# Altium schematic sheet LED.SchDoc(LED)
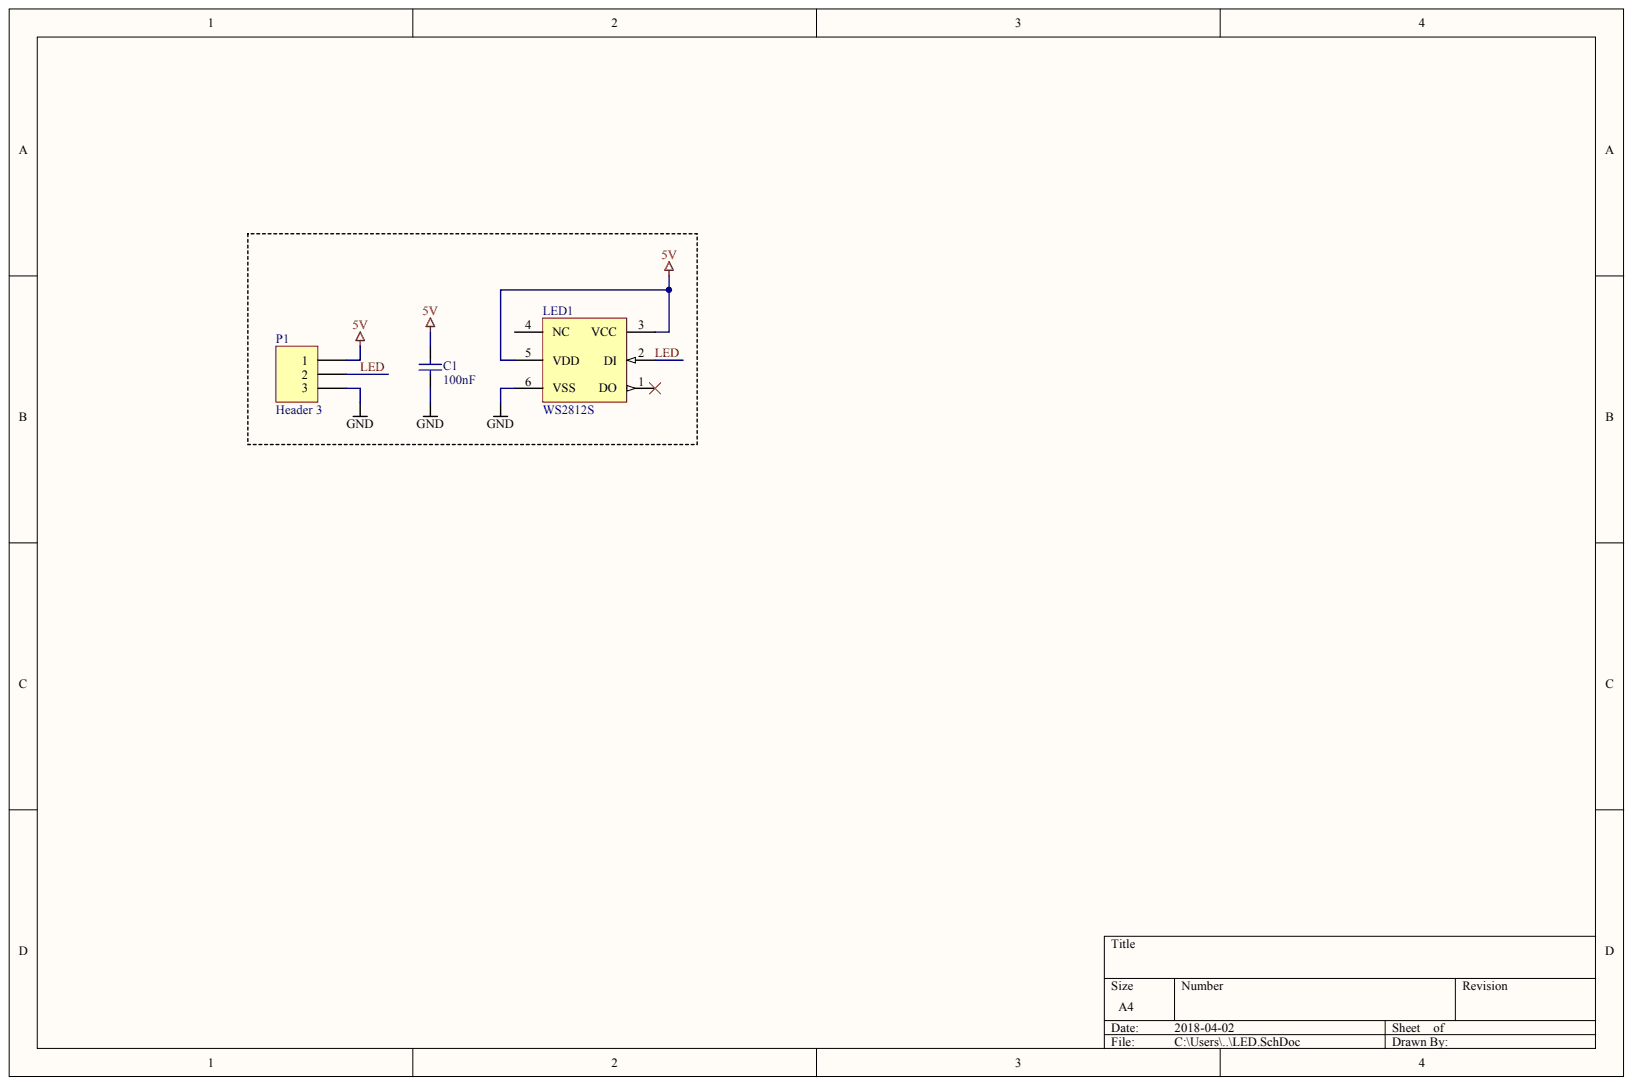
[diagram p0: LED.SchDoc]

## Parts
C1  100nF
LED1  WS2812S
P1  Header 3

## Nets
5V: C1.1 LED1.3(VCC) LED1.5(VDD) P1.1
GND: C1.2 LED1.6(VSS) P1.3
LED: LED1.2(DI) P1.2

Not connected: LED1 1(DO) 4(NC)
